# Altium schematic sheet main.SchDoc
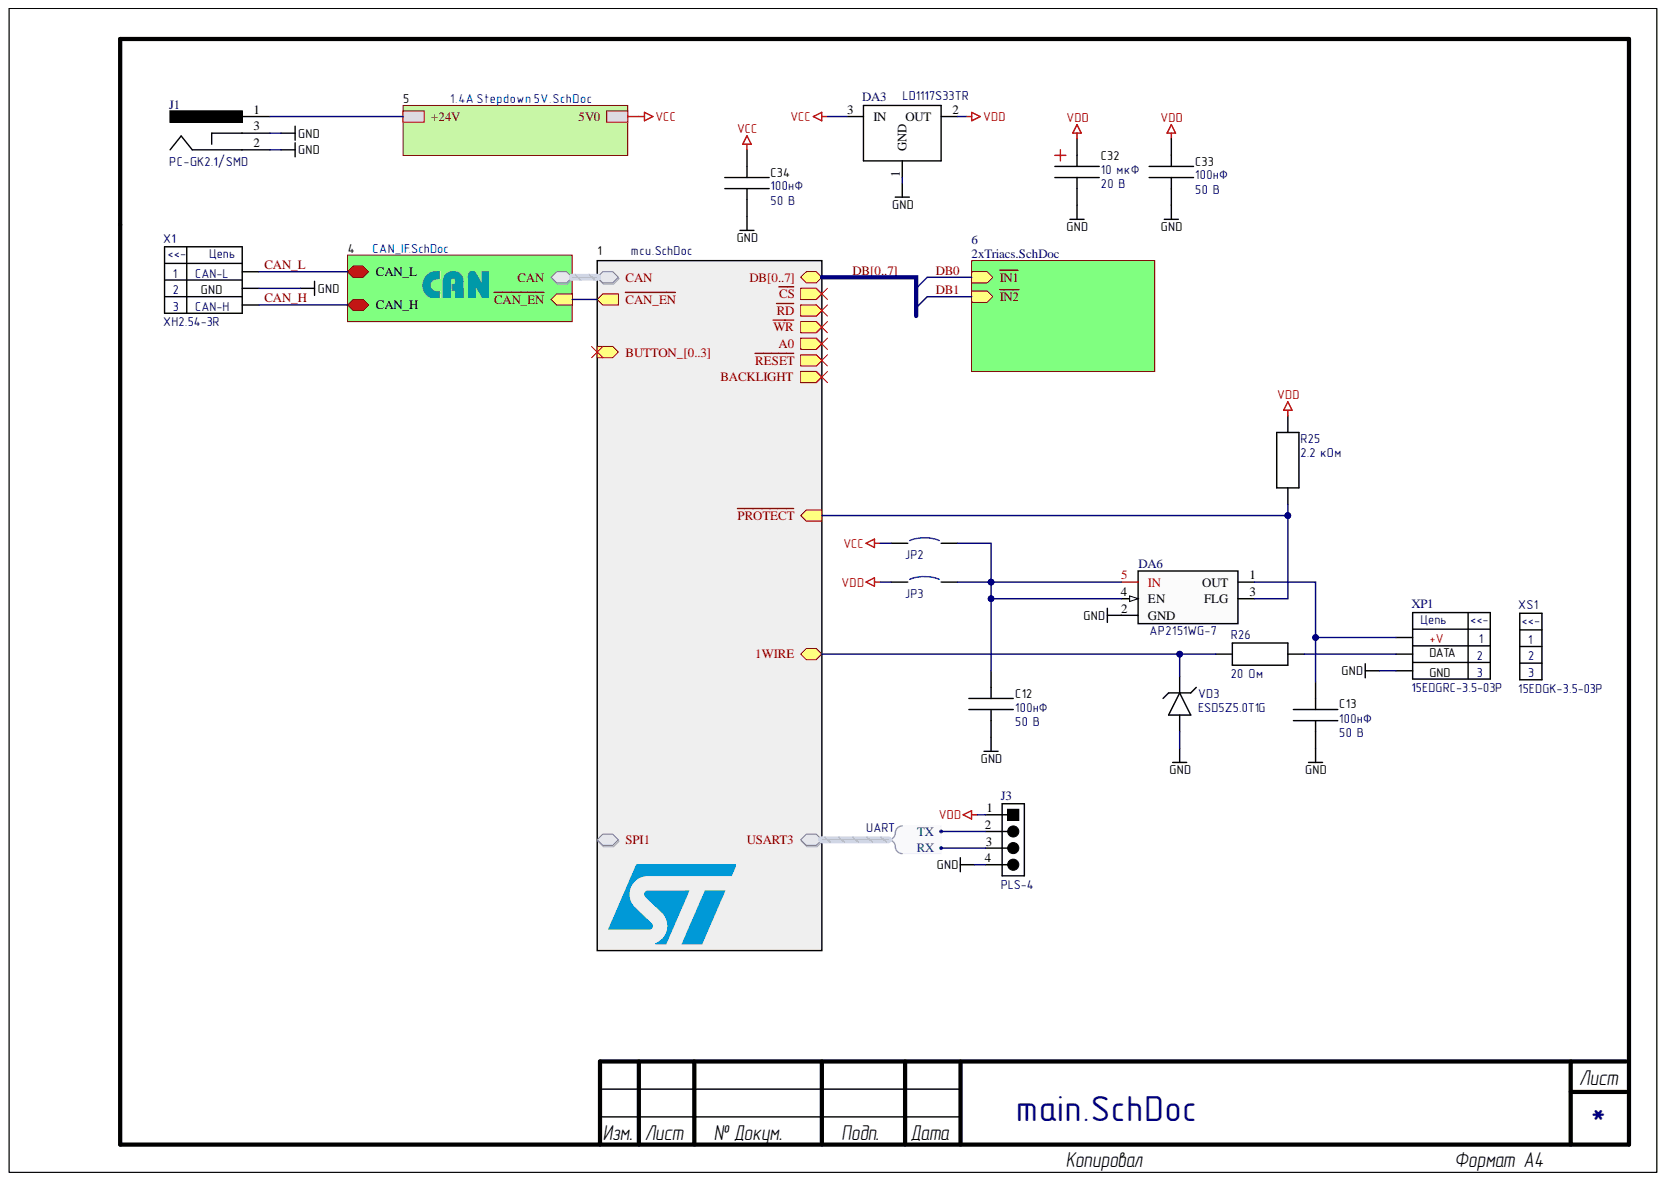
[diagram p0: main.SchDoc]

## Parts
C12  Part Number: 100нФ 50 В  showvalue: 100нФ  VOLTAGE: 50 В  [C0805]  (hidden comment: 100нФ 10% 50 В 0805 X7R)
C13  Part Number: 100нФ 50 В  showvalue: 100нФ  VOLTAGE: 50 В  [C0805]  (hidden comment: 100нФ 10% 50 В 0805 X7R)
C32  showvalue: 10 мкФ  VOLTAGE: 20 В  [CASE_B]  (hidden comment: 10uF 20V (B))
C33  Part Number: 100нФ 50 В  showvalue: 100нФ  VOLTAGE: 50 В  [C0805]  (hidden comment: 100нФ 10% 50 В 0805 X7R)
C34  Part Number: 100нФ 50 В  showvalue: 100нФ  VOLTAGE: 50 В  [C0805]  (hidden comment: 100нФ 10% 50 В 0805 X7R)
DA3  LD1117S33TR  [SOT-223]
DA6  AP2151WG-7  [SOT-25]
J1  PC-GK2.1/SMD  [pc-gk2.1_smd]
J3  PLS-4  [HEADER 1X4]
JP2  Jumper  [SB_0603]  (value not drawn)
JP3  Jumper  [SB_0603]  (value not drawn)
R25  showvalue: 2.2 кОм  [R0805]  (hidden comment: 2.2 кОм 0805 5%)
R26  value 20  showvalue: 20 Ом  [R0805]  (hidden comment: 20 Ом 0805 5%)
VD3  ESD5Z5.0T1G  [SOD-523]
X1  XH2.54-3R  [XH2.54-3R]
XP1  15EDGRC-3.5-03P  [15EDGRC-3.5-03P]
XS1  15EDGK-3.5-03P  [15EDGK-3.5-03P]

## Nets
GND: C12.2 C13.2 C32.2 C33.2 C34.2 DA3.1 DA6.2(GND) J1.2 J1.3 J3.4 VD3.1 X1.2 XP1.3
VCC: C34.1 DA3.3 JP2.1
VDD: C32.1 C33.1 DA3.2 J3.1 JP3.1 R25.2
CAN_H: X1.3
CAN_L: X1.1
NetC12_1: C12.1 DA6.4(EN) DA6.5(IN) JP2.2 JP3.2
NetC13_1: C13.1 DA6.1(OUT) XP1.1
NetDA6_3: DA6.3(FLG) R25.1
NetJ1_1: J1.1
NetJ3_2: J3.2
NetJ3_3: J3.3
NetR26_1: R26.1 VD3.2
NetR26_2: R26.2 XP1.2
~{CAN_EN}:
~{CS}:
~{RD}:
~{RESET}:
~{WR}:
A0:
BACKLIGHT:
BUTTON_0:
BUTTON_1:
BUTTON_2:
BUTTON_3:
CAN.RX:
CAN.TX:
DB0:  [also labelled DB]
DB1:  [also labelled DB]
DB2:  [also labelled DB]
DB3:  [also labelled DB]
DB4:  [also labelled DB]
DB5:  [also labelled DB]
DB6:  [also labelled DB]
DB7:  [also labelled DB]
SPI1:

## Library data of the parts
C12 C13 C33 C34 = capacitor: конденсатор керамический SMD; articul: 399; value: 100n; Component Kind: Standard; Component Type: Standard; Library Name: Capacitors 0805 X7R.IntLib; Pin Count: 2; Simulation: Model Name
C32 = Tantal_capacitor: конденсатор танталовый; articul: 270; value: 10u; Component Kind: Standard; Component Type: Standard; Library Name: Capacitors Tantalum.IntLib; Part Number: 10uF 20V (B); Pin Count: 2; HelpURL: d:\doc\datasheets\-COMPONENTS\Capacitors\AVX\taj.pdf
DA3 = LD1117S33TR: Positive 3.3V SOT-223; articul: 81; Component Kind: Standard; Library Name: PMIC Linear Regulators.IntLib; NAME: LD1117S33TR; HelpURL: d:\doc\datasheets\L\Ld\LD1117.pdf
DA6 = AP2151WG-7: CURRENT-LIMITED POWER SWITCH; articul: 2704; Component Kind: Standard; Library Name: PMIC Gate Drivers & Switches.IntLib; NAME: AP2151WG-7; HelpURL: d:\doc\datasheets\A\AP\AP2141-51.pdf
J1 = PC-GK2.1/SMD: DC Power Jack, 24 V, 3.5 A; articul: 2690; Component Kind: Standard; Library Name: Connectors.IntLib; NAME: PC-GK2.1/SMD; HelpURL: d:\doc\datasheets\P\PC\pcgk2xSMD.pdf
J3 = PLS-4: Штырьки на плату шаг 2,54 мм; articul: 225; slice: 0.1; Component Kind: Standard; Library Name: Connectors IDC & PLS.IntLib; NAME: PLS-4
JP2 JP3 = Solder jumper (0603): Посадочное место под перемычку оловом; Component Kind: Standard; NAME: Jumper; Library Name: Механические части.IntLib
R25 = 2.2 кОм 0805 5%: резистор постоянный; articul: 551; VALUE: 2.2k; Component Kind: Standard; Component Type: Standard; Designator: 2.2 кОм 0805 5%; Library Name: Resistors 0805 5%.IntLib; Part Number: 2.2 кОм 0805 5%; Pin Count: 2; Simulation: Res
R26 = 20 Ом 0805 5%: резистор постоянный; articul: 594; Component Kind: Standard; Component Type: Standard; Designator: 20 Ом 0805 5%; Library Name: Resistors 0805 5%.IntLib; Part Number: 20 Ом 0805 5%; Pin Count: 2; Simulation: Res
VD3 = ESD5Z5.0T1G: Micro−Packaged Diodes for ESD Protection; articul: 2707; HelURL: d:\doc\datasheets\E\ES\ESD5Z2.5T1-D.PDF; Urwm: 5.0V; Component Kind: Standard; Library Name: ESD protection.IntLib; NAME: ESD5Z5.0T1G
X1 = XH2.54-3R: разъем аерии XH2.54 3конт. угловая вилка в плату; articul: 2691; Component Kind: Standard; Library Name: Connectors.IntLib; NAME: XH2.54-3R
XP1 = 15EDGRC-3.5-03P: articul: 2715; Component Kind: Standard; NAME: 15EDGRC-3.5-03P; Library Name: Terminals.IntLib
XS1 = 15EDGK-3.5-03P: Розетка на кабель; articul: 2711; Component Kind: Standard; NAME: 15EDGK-3.5-03P; Library Name: Terminals.IntLib
